# Altium schematic sheet Power_Switch.SchDoc(Power_Switch)
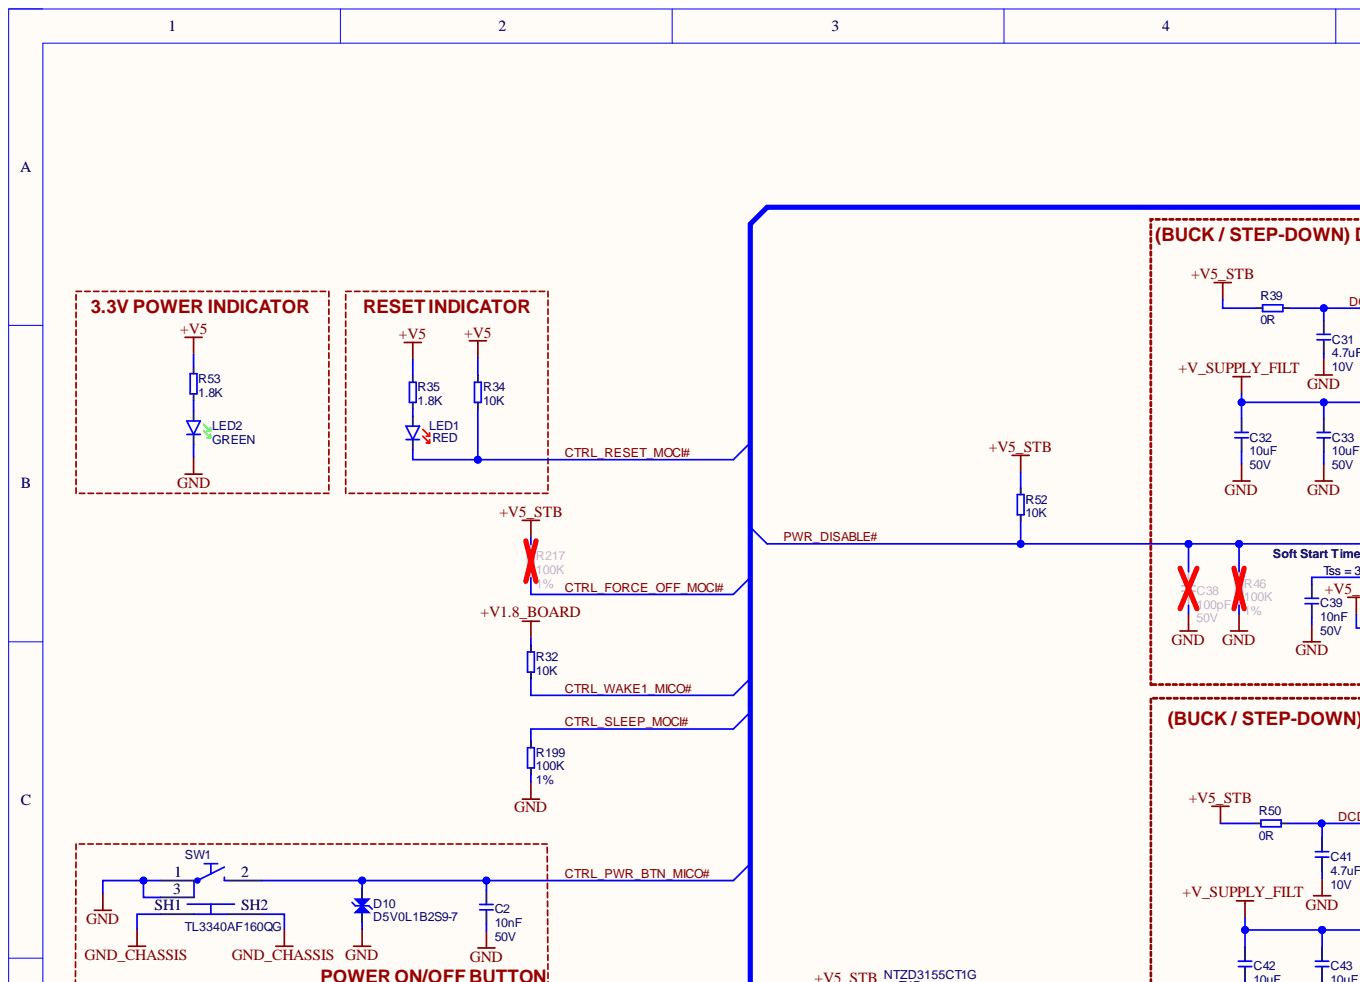
[diagram p0: Power_Switch.SchDoc, top left]
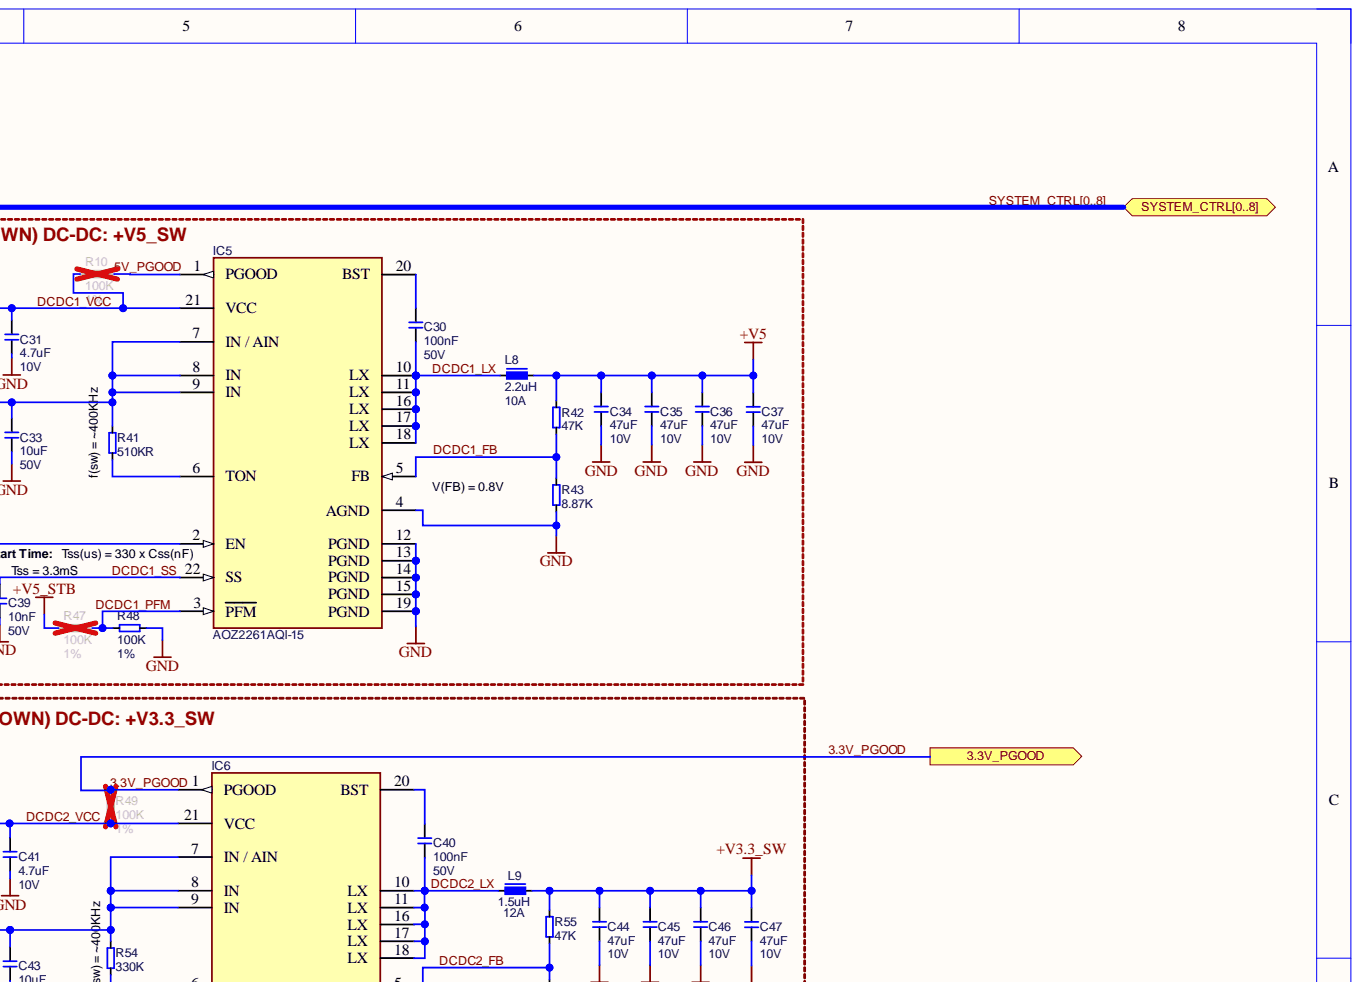
[diagram p1: Power_Switch.SchDoc, top right]
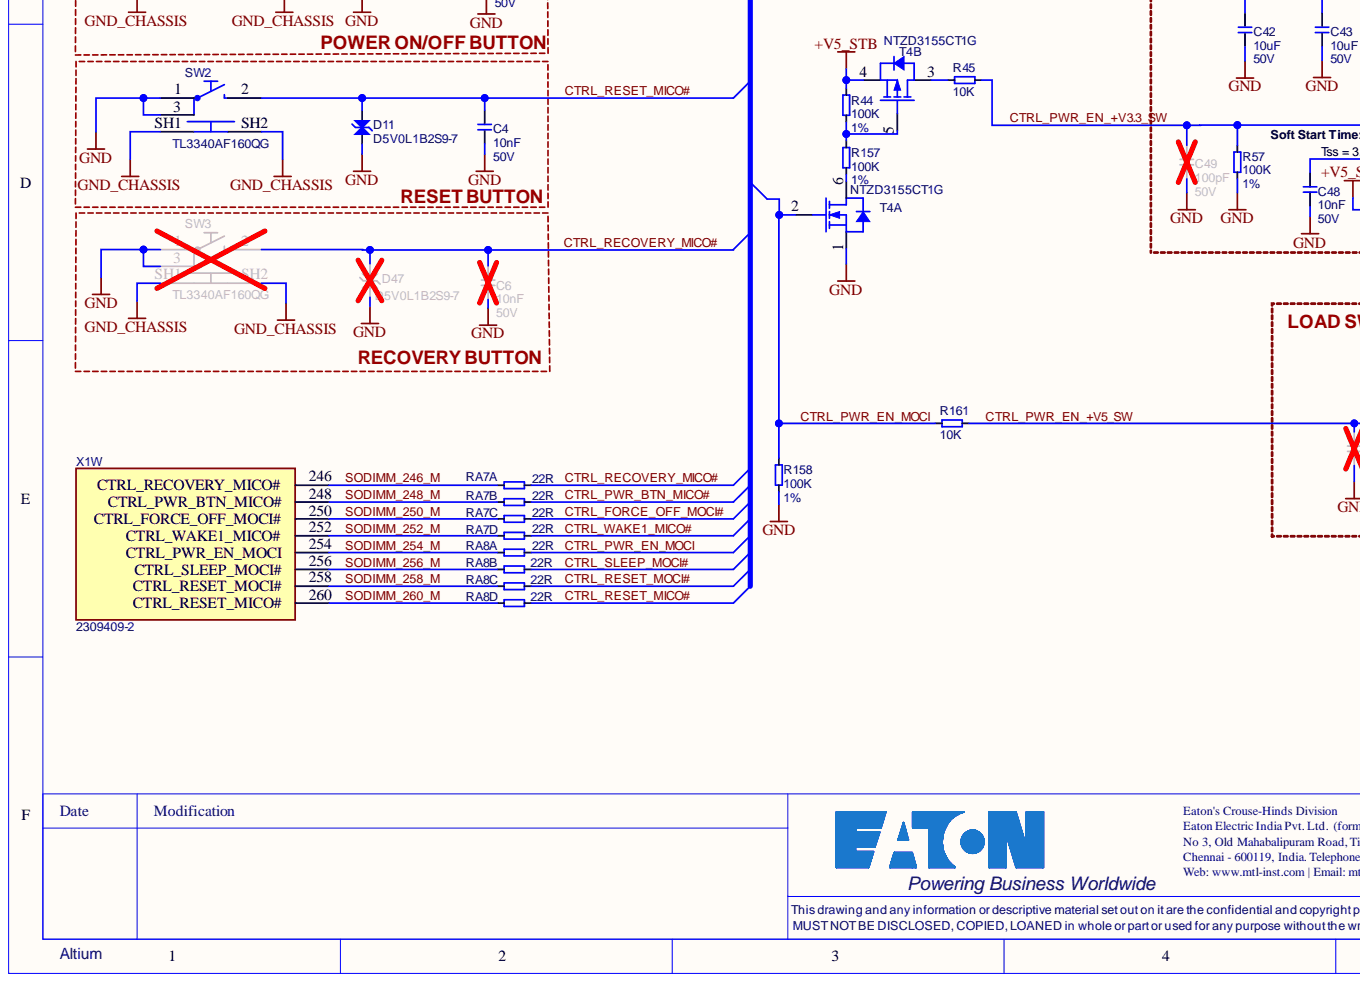
[diagram p2: Power_Switch.SchDoc, bottom left]
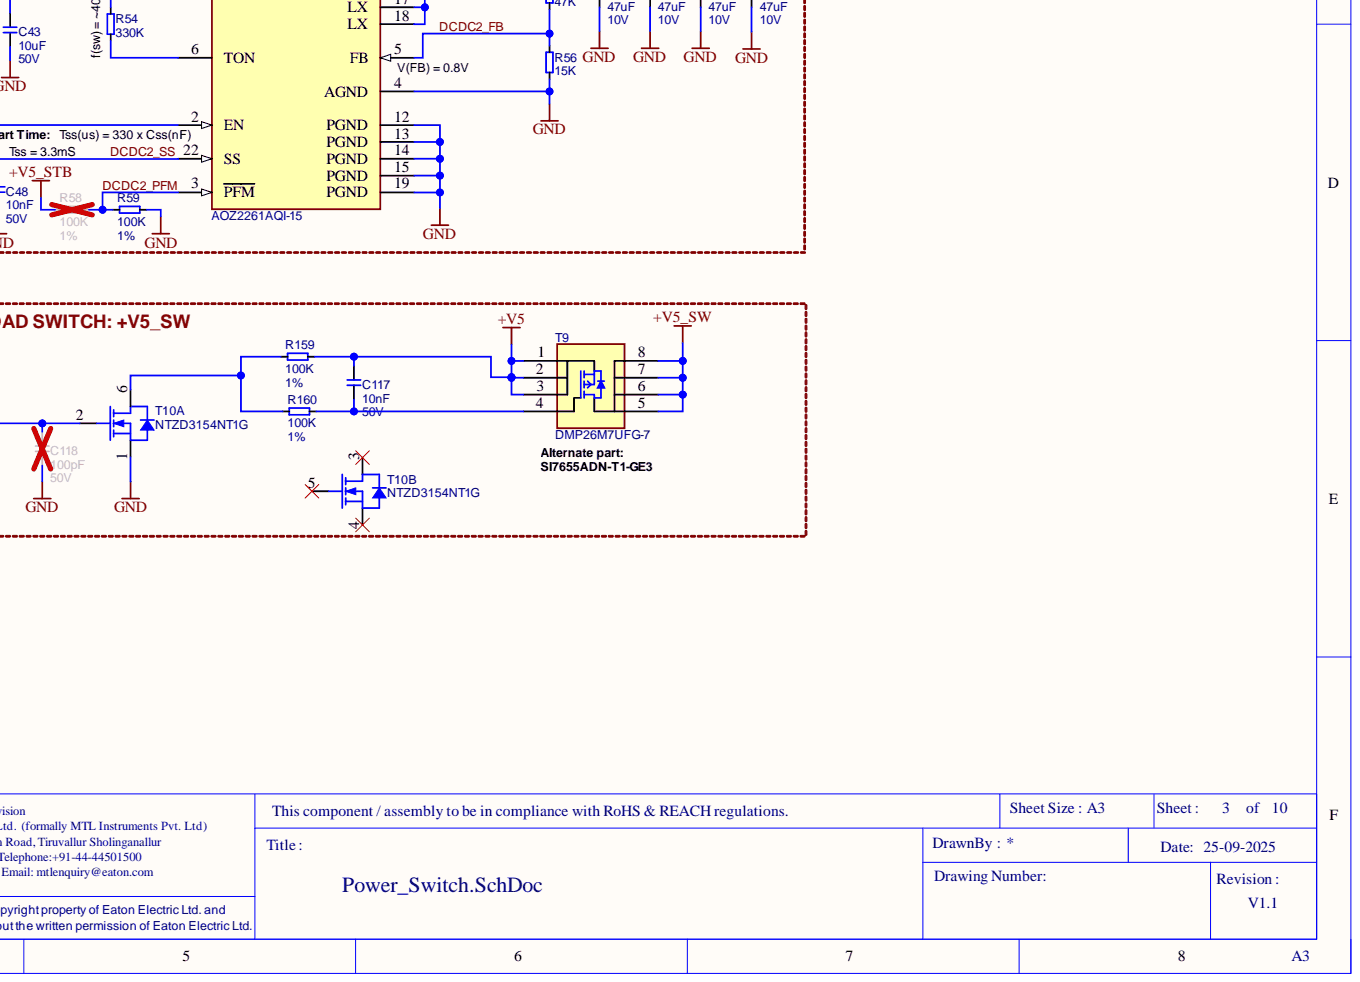
[diagram p3: Power_Switch.SchDoc, bottom right]

## Parts
C2  10nF  Rating: 50V  [CAPC1005X60N]
C4  10nF  Rating: 50V  [CAPC1005X60N]
C6  Rating: 50V  [CAPC1005X60N]  (hidden comment: 10nF)
C30  100nF  Rating: 50V  [T_CAPC0603X33N]
C31  4.7uF  Rating: 10V  [T_CAPC0603X33N]
C32  10uF  Rating: 50V  [T_CAPC1206X60N]
C33  10uF  Rating: 50V  [T_CAPC1206X60N]
C34  47uF  Rating: 10V  [T_CAPC0805X125N]
C35  47uF  Rating: 10V  [T_CAPC0805X125N]
C36  47uF  Rating: 10V  [T_CAPC0805X125N]
C37  47uF  Rating: 10V  [T_CAPC0805X125N]
C38  Rating: 50V  [CAPC1005X60N]  (hidden comment: 100pF)
C39  10nF  Rating: 50V  [CAPC1005X60N]
C40  100nF  Rating: 50V  [T_CAPC0603X33N]
C41  4.7uF  Rating: 10V  [T_CAPC0603X33N]
C42  10uF  Rating: 50V  [T_CAPC1206X60N]
C43  10uF  Rating: 50V  [T_CAPC1206X60N]
C44  47uF  Rating: 10V  [T_CAPC0805X125N]
C45  47uF  Rating: 10V  [T_CAPC0805X125N]
C46  47uF  Rating: 10V  [T_CAPC0805X125N]
C47  47uF  Rating: 10V  [T_CAPC0805X125N]
C48  10nF  Rating: 50V  [CAPC1005X60N]
C49  Rating: 50V  [CAPC1005X60N]  (hidden comment: 100pF)
C117  10nF  Rating: 50V  [CAPC1005X60N]
C118  Rating: 50V  [CAPC1005X60N]  (hidden comment: 100pF)
D10  D5V0L1B2S9-7  [D-DIODES-SOD-923]
D11  D5V0L1B2S9-7  [D-DIODES-SOD-923]
D47  D5V0L1B2S9-7  [D-DIODES-SOD-923]
IC5  AOZ2261AQI-15  [T_QFN50P400X400X100-22N]
IC6  AOZ2261AQI-15  [T_QFN50P400X400X100-22N]
L8  2.2uH  I (RMS): 10A  [T_INDM7366]
L9  1.5uH  I (RMS): 12A  [T_INDM7366]
LED1  Color: RED  [T_Liteon_LTST-C190KRKT]  (hidden comment: LTST-C190KRKT)
LED2  Color: GREEN  [T_Liteon_LTST-C190GKT]  (hidden comment: LTST-C190GKT)
R10  100K  Tolerance: 1%  [RESC1005X40N]
R32  10K  [RESC1005X40N]
R34  10K  [RESC1005X40N]
R35  1.8K  [RESC1005X40N]
R39  0R  [RESC1005X40N]
R41  510K  value 510KR  [RESC1005X40N]
R42  47K  [RESC1005X40N]
R43  8.87K  [RESC1005X40N]
R44  100K  Tolerance: 1%  [RESC1005X40N]
R45  10K  [RESC1005X40N]
R46  100K  Tolerance: 1%  [RESC1005X40N]
R47  100K  Tolerance: 1%  [RESC1005X40N]
R48  100K  Tolerance: 1%  [RESC1005X40N]
R49  100K  Tolerance: 1%  [RESC1005X40N]
R50  0R  [RESC1005X40N]
R52  10K  [RESC1005X40N]
R53  1.8K  [RESC1005X40N]
R54  330K  [RESC1005X40N]
R55  47K  [RESC1005X40N]
R56  15K  [RESC1005X40N]
R57  100K  Tolerance: 1%  [RESC1005X40N]
R58  100K  Tolerance: 1%  [RESC1005X40N]
R59  100K  Tolerance: 1%  [RESC1005X40N]
R157  100K  Tolerance: 1%  [RESC1005X40N]
R158  100K  Tolerance: 1%  [RESC1005X40N]
R159  100K  [RESC1005X40N]
R160  100K  Tolerance: 1%  [RESC1005X40N]
R161  10K  [RESC1005X40N]
R199  100K  Tolerance: 1%  [RESC1005X40N]
R217  100K  Tolerance: 1%  [RESC1005X40N]
RA7  22R  [T_Resistor_Array_0402_X4]  (parts A,B,C,D)
RA8  22R  [T_Resistor_Array_0402_X4]  (parts A,B,C,D)
SW1  TL3340AF160QG  [T_E-SWITCH- TL3340AF160QG]
SW2  TL3340AF160QG  [T_E-SWITCH- TL3340AF160QG]
SW3  TL3340AF160QG  [T_E-SWITCH- TL3340AF160QG]
T4  NTZD3155CT1G  [SOTFL50P160X60-6N]  (parts A,B)
T9  DMP26M7UFG-7  [T_DFN3333]
T10  Manufacturer Part No.: NTZD3154NT1G  [SOTFL50P160X60-6N]  (hidden comment: T-ONSemi-NTZD3154NT1G)  (parts A,B)
X1  2309409-2  [CON-TE-2309409-2]  (part W)

## Ports
3.3V_PGOOD, SYSTEM_CTRL0, SYSTEM_CTRL1, SYSTEM_CTRL2, SYSTEM_CTRL3, SYSTEM_CTRL4, SYSTEM_CTRL5, SYSTEM_CTRL6, SYSTEM_CTRL7, SYSTEM_CTRL8, SYSTEM_CTRL[0..8]

## Nets
+V1.8_BOARD: R32.2
+V3.3_SW: C44.2 C45.2 C46.2 C47.2 L9.2 R55.2
+V5: C34.2 C35.2 C36.2 C37.2 C117.2 L8.2 R34.2 R35.2 R42.2 R53.1 R159.1 T9.1 T9.2 T9.3
+V5_STB: R39.1 R44.1 R47.1 R50.1 R52.2 R58.1 R217.2 T4.4
+V5_SW: T9.5 T9.6 T9.7 T9.8
+V_SUPPLY_FILT: C32.1 C33.1 C42.1 IC5.7(IN_/_AIN) IC5.8(IN) IC5.9(IN) IC6.7(IN_/_AIN) IC6.8(IN) IC6.9(IN) R41.2 R54.2
GND: C2.1 C4.1 C6.1 C31.1 C32.2 C33.2 C34.1 C35.1 C36.1 C37.1 C38.1 C39.1 C41.1 C42.2 C43.2 C44.1 C45.1 C46.1 C47.1 C48.1 C49.1 C118.1 D10.2 D11.2 D47.2 IC5.4(AGND) IC5.12(PGND) IC5.13(PGND) IC5.14(PGND) IC5.15(PGND) IC5.19(PGND) IC6.4(AGND) IC6.12(PGND) IC6.13(PGND) IC6.14(PGND) IC6.15(PGND) IC6.19(PGND) LED2.K R43.1 R46.1 R48.2 R56.1 R57.1 R59.2 R158.1 R199.1 SW1.1 SW1.3 SW2.1 SW2.3 SW3.1 SW3.3 T4.1 T10.1
GND_CHASSIS: SW1.SH1 SW1.SH2 SW2.SH1 SW2.SH2 SW3.SH1 SW3.SH2
3.3V_PGOOD: IC6.1(PGOOD) R49.2 ; port 3.3V_PGOOD
5V_PGOOD: IC5.1(PGOOD) R10.2
CTRL_FORCE_OFF_MOCI#: R217.1 RA7.7
CTRL_PWR_BTN_MICO#: C2.2 D10.1 RA7.6 SW1.2
CTRL_PWR_EN_+V3.3_SW: C49.2 IC6.2(EN) R45.1 R57.2
CTRL_PWR_EN_+V5_SW: C118.2 R161.1 T10.2
CTRL_PWR_EN_MOCI: R158.2 R161.2 RA8.5 T4.2
CTRL_RECOVERY_MICO#: C6.2 D47.1 RA7.5 SW3.2
CTRL_RESET_MICO#: C4.2 D11.1 RA8.8 SW2.2
CTRL_RESET_MOCI#: LED1.K R34.1 RA8.7
CTRL_SLEEP_MOCI#: R199.2 RA8.6
CTRL_WAKE1_MICO#: R32.1 RA7.8
DCDC1_FB: IC5.5(FB) R42.1 R43.2
DCDC1_LX: C30.1 IC5.10(LX) IC5.11(LX) IC5.16(LX) IC5.17(LX) IC5.18(LX) L8.1
DCDC1_SS: C39.2 IC5.22(SS)
DCDC1_VCC: C31.2 IC5.21(VCC) R10.1 R39.2
DCDC2_FB: IC6.5(FB) R55.1 R56.2
DCDC2_LX: C40.1 IC6.10(LX) IC6.11(LX) IC6.16(LX) IC6.17(LX) IC6.18(LX) L9.1
DCDC2_PFM: IC6.3(PFM) R58.2 R59.1
DCDC2_SS: C48.2 IC6.22(SS)
DCDC2_VCC: C41.2 IC6.21(VCC) R49.1 R50.2
PWR_DISABLE#: C38.2 IC5.2(EN) R46.2 R52.1
SODIMM_246_M: RA7.1 X1.246(CTRL_RECOVERY_MICO#)
SODIMM_248_M: RA7.2 X1.248(CTRL_PWR_BTN_MICO#)
SODIMM_250_M: RA7.3 X1.250(CTRL_FORCE_OFF_MOCI#)
SODIMM_252_M: RA7.4 X1.252(CTRL_WAKE1_MICO#)
SODIMM_254_M: RA8.1 X1.254(CTRL_PWR_EN_MOCI)
SODIMM_256_M: RA8.2 X1.256(CTRL_SLEEP_MOCI#)
SODIMM_258_M: RA8.3 X1.258(CTRL_RESET_MOCI#)
SODIMM_260_M: RA8.4 X1.260(CTRL_RESET_MICO#)
NetC30_2: C30.2 IC5.20(BST)
NetC40_2: C40.2 IC6.20(BST)
NetC117_1: C117.1 R160.1 T9.4
NetIC5_3: IC5.3(PFM) R47.2 R48.1
NetIC5_6: IC5.6(TON) R41.1
NetIC6_6: IC6.6(TON) R54.1
NetLED1_A: LED1.A R35.1
NetLED2_A: LED2.A R53.2
NetR44_2: R44.2 R157.2 T4.5
NetR45_2: R45.2 T4.3
NetR157_1: R157.1 T4.6
NetR159_2: R159.2 R160.2 T10.6
DCDC1_PFM:
SYSTEM_CTRL0: ; port SYSTEM_CTRL[0..8]  [also labelled SYSTEM_CTRL]
SYSTEM_CTRL1: ; port SYSTEM_CTRL[0..8]  [also labelled SYSTEM_CTRL]
SYSTEM_CTRL2: ; port SYSTEM_CTRL[0..8]  [also labelled SYSTEM_CTRL]
SYSTEM_CTRL3: ; port SYSTEM_CTRL[0..8]  [also labelled SYSTEM_CTRL]
SYSTEM_CTRL4: ; port SYSTEM_CTRL[0..8]  [also labelled SYSTEM_CTRL]
SYSTEM_CTRL5: ; port SYSTEM_CTRL[0..8]  [also labelled SYSTEM_CTRL]
SYSTEM_CTRL6: ; port SYSTEM_CTRL[0..8]  [also labelled SYSTEM_CTRL]
SYSTEM_CTRL7: ; port SYSTEM_CTRL[0..8]  [also labelled SYSTEM_CTRL]
SYSTEM_CTRL8: ; port SYSTEM_CTRL[0..8]  [also labelled SYSTEM_CTRL]

Not connected: C43 1; T10 3 4 5

## Library data of the parts
C2 C4 C6 C39 C48 C117 = C-X7R-10nF-50V-10%-0402: Capacitor Ceramic X7R 10nF 50V 10% 0402; Manufacturer Name: KEMET; Manufacturer Part No.: C0402C103K5REC7411; Component Kind: Standard; Library Name: SoM_Camera_Encoder.SCHLIB; Tolerance: 10%; Type: X7R; Value: 10nF
C30 C40 = C-X7R-100nF-50V-10%-0603: Capacitor Ceramic X7R 100nF 50V 10% 0603; Manufacturer Name: KEMET; Manufacturer Part No.: C0603C104K5RACTU; Component Kind: Standard; Library Name: SoM_Camera_Encoder.SCHLIB; Tolerance: 10%; Type: X7R; Value: 100nF
C31 C41 = C-X5R-4.7uF-10V-10%-0603: Capacitor Ceramic X5R 4.7uF 10V 10% 0603; Manufacturer Name: Samsung Electro-Mechanics; Manufacturer Part No.: CL10A475KP8NNNC; Component Kind: Standard; Library Name: SoM_Camera_Encoder.SCHLIB; Tolerance: 10%; Type: X5R; Value: 4.7uF
C32 C33 C42 C43 = C-X5R-10uF-50V-20%-1206: Capacitor Ceramic X5R 10uF 50V 20% 1206; Manufacturer Name: Taiyo Yuden; Manufacturer Part No.: UMK316BBJ106ML-T; Component Kind: Standard; Library Name: SoM_Camera_Encoder.SCHLIB; Tolerance: 20%; Type: X5R; Value: 10uF
C34-C37 C44-C47 = C-X5R-47uF-10V-20%-0805: Capacitor Ceramic X5R 47uF 10V 20% 0805; Manufacturer Name: Cal-Chip Electronics, Inc.; Manufacturer Part No.: GMC21X5R476M10NT; ClassName: Power_Poly; Component Kind: Standard; Library Name: SoM_Camera_Encoder.SCHLIB; Tolerance: 20%; Type: X5R; Value: 47uF
C38 C49 C118 = C-X7R-100pF-50V-10%-0402: Capacitor Ceramic X7R 100pF 50V 10% 0402; Manufacturer Name: YAGEO; Manufacturer Part No.: CC0402KRX7R9BB101; Component Kind: Standard; Library Name: SoM_Camera_Encoder.SCHLIB; Tolerance: 10%; Type: X7R; Value: 100pF
D10 D11 D47 = D-Diodes-D5V0L1B2S9-7: BIDIRECTIONAL TVS DIODE 5V  SOD923; Manufacturer Name: Diodes; Component Kind: Standard; Manufacturer Part No.: D5V0L1B2S9-7; Library Name: Mallow.SchLib
IC5 IC6 = IC-A&O-AOZ2261AQI-15: 8A (Iout(max)) Synchronous Buck Regulator, QFN-22L (4mmx 4mm), Vin = 4V to 28V; Manufacturer Name: Alpha and Omega; Component Kind: Standard; Manufacturer Part No.: AOZ2261AQI-15; Library Name: Mallow.SchLib
L8 = L-Laird-MGV06052R2M-10-2.2uH-10A-20%: Inductance 2.2uH, 20%, Irms=10A, Isat=14A, DCR=12mOhm; Manufacturer Name: Laird; I (saturation): 14A; Component Kind: Standard; Manufacturer Part No.: MGV06052R2M-10; Rating: 10A; Tolerance: 20%; Value: 2.2uH; Library Name: Mallow.SchLib
L9 = L-Pulse-PA5404.152NLT-1.5uH-12A-20%: Inductance 1.5uH, 20%, Irms=12A, Isat=16.5A, DCR=9mOhm; Manufacturer Name: Pulse; I (saturation): 16.5A; Component Kind: Standard; Manufacturer Part No.: PA5404.152NLT; Rating: 12A; Tolerance: 20%; Value: 1.5uH; Library Name: Mallow.SchLib
LED1 = LED-LiteOn-LTST-C190KRKT: LED red, Lite-On LTST-C190KRKT; Component Kind: Standard; Manufacturer Name: Lite-On; Manufacturer Part No.: LTST-C190KRKT; Library Name: Mallow.SchLib
LED2 = LED-LiteOn-LTST-C190GKT: LED green Lite-ON LTST-C190GKT; Component Kind: Standard; Manufacturer Name: Lite-ON; Manufacturer Part No.: LTST-C190GKT; Library Name: Mallow.SchLib
R10 R44 R46-R49 R57-R59 R157-R160 R199 R217 = R-100K-63mW-1%-0402: Resistor 100 Kohm 63mW 1% 0402; Manufacturer Name: Vishay Dale; Manufacturer Part No.: CRCW0402100KFKED; Component Kind: Standard; Library Name: SoM_Camera_Encoder.SCHLIB; Rating: 63mW; Value: 100K
  R159: Tolerance: 1%
R32 R34 R45 R52 R161 = R-10K-63mW-5%-0402: Resistor 10 Kohm 63mW 5% 0402; Manufacturer Name: YAGEO; Manufacturer Part No.: RC0402JR-0710KL; Component Kind: Standard; Library Name: SoM_Camera_Encoder.SCHLIB; Rating: 63mW; Tolerance: 5%; Value: 10K
R35 R53 = R-1.8K-63mW-5%-0402: Resistor 1.8 Kohm 63mW 5% 0402; Manufacturer Name: KOA Speer Electronics, Inc.; Manufacturer Part No.: RK73B1ETTP182J; Component Kind: Standard; Library Name: SoM_Camera_Encoder.SCHLIB; Rating: 63mW; Tolerance: 5%; Value: 1.8K
R39 R50 = R-0R-63mW-1%-0402: Resistor 0 ohm 63mW 1% 0402; Manufacturer Name: Thin Film Technology Corp.; Manufacturer Part No.: TFAS0402WJUMPF-T10; Component Kind: Standard; Library Name: SoM_Camera_Encoder.SCHLIB; Rating: 63mW; Tolerance: 1%; Value: 0R
R41 = R-510K-63mW-1%-0402: Resistor 510 Kohm 63mW 1% 0402; Component Kind: Standard; Library Name: SoM_Camera_Encoder.SCHLIB; Rating: 63mW; Tolerance: 1%
R42 R55 = R-47K-63mW-1%-0402: Resistor 47 Kohm 63mW 1% 0402; Component Kind: Standard; Rating: 63mW; Tolerance: 1%; Value: 47K; Library Name: Mallow.SchLib
R43 = R-8.87KR-63mW-1%-0402: Resistor 8.87 Kohm 63mW 1% 0402; Manufacturer Name: Vishay Dale; Manufacturer Part No.: CRCW04028K87FKED; Value: 8.87KR; Component Kind: Standard; Library Name: SoM_Camera_Encoder.SCHLIB; Rating: 63mW; Tolerance: 1%
R54 = R-330KR-63mW-1%-0402: Resistor 330 Kohm 63mW 1% 0402; Manufacturer Name: TE Connectivity Passive Product; Manufacturer Part No.: CRGCQ0402F330K; Value: 330KR; Component Kind: Standard; Library Name: SoM_Camera_Encoder.SCHLIB; Rating: 63mW; Tolerance: 1%
R56 = R-15K-63mW-1%-0402: Resistor 15 Kohm 63mW 1% 0402; Manufacturer Name: YAGEO; Manufacturer Part No.: AC0402FR-0715KL; Component Kind: Standard; Library Name: SoM_Camera_Encoder.SCHLIB; Rating: 63mW; Tolerance: 1%; Value: 15K
RA7 RA8 = RA-22R-63mW-5%-0804-div: Resistor Array 22 R 63mW 5% 0804, Concave type; Component Kind: Standard; Library Name: SoM_Camera_Encoder.SCHLIB; Power Dissipation: 63mW; Tolerance: 5%; Value: 22R
SW1-SW3 = SW-E-Switch-TL3340AF160QG: SWITCH TACT SUB MINI 160GF SMD Right Angle; Component Kind: Standard; Manufacturer Name: E-Switch; Manufacturer Part No.: TL3340AF160QG; Library Name: Mallow.SchLib
T4 = T-ONSemi-NTZD3155CT1G: N- and P-Channel MOSFET 20V; Manufacturer Name: ONSemi; Component Kind: Standard; Manufacturer Part No.: NTZD3155CT1G; Library Name: Mallow.SchLib
T9 = T-Diodes-DMP26M7UFG-7: p-channel MOSFET; Manufacturer Name: DIODES; Component Kind: Standard; Manufacturer Part No.: DMP26M7UFG-7; Library Name: Mallow.SchLib
T10 = T-ONSemi-NTZD3154NT1G: Dual N-Channel 20V (D-S)  MOSFET; Manufacturer Name: ONSemi; Component Kind: Standard; Library Name: Mallow.SchLib
X1 = CON-TE-2309409-2: DDR4 SODIMM Socket, 260 pins, 5.2mm(H), 0.5mm Pitch; Manufacturer Name: TE Connectivity; Component Kind: Standard; Manufacturer Part No.: 2309409-2; Package: DDR4-SODIMM-260; Temperature Range: -40C to +125C; Library Name: Mallow.SchLib
